# Altium schematic sheet Coil Interface Board.SchDoc("Coil Interface Board")
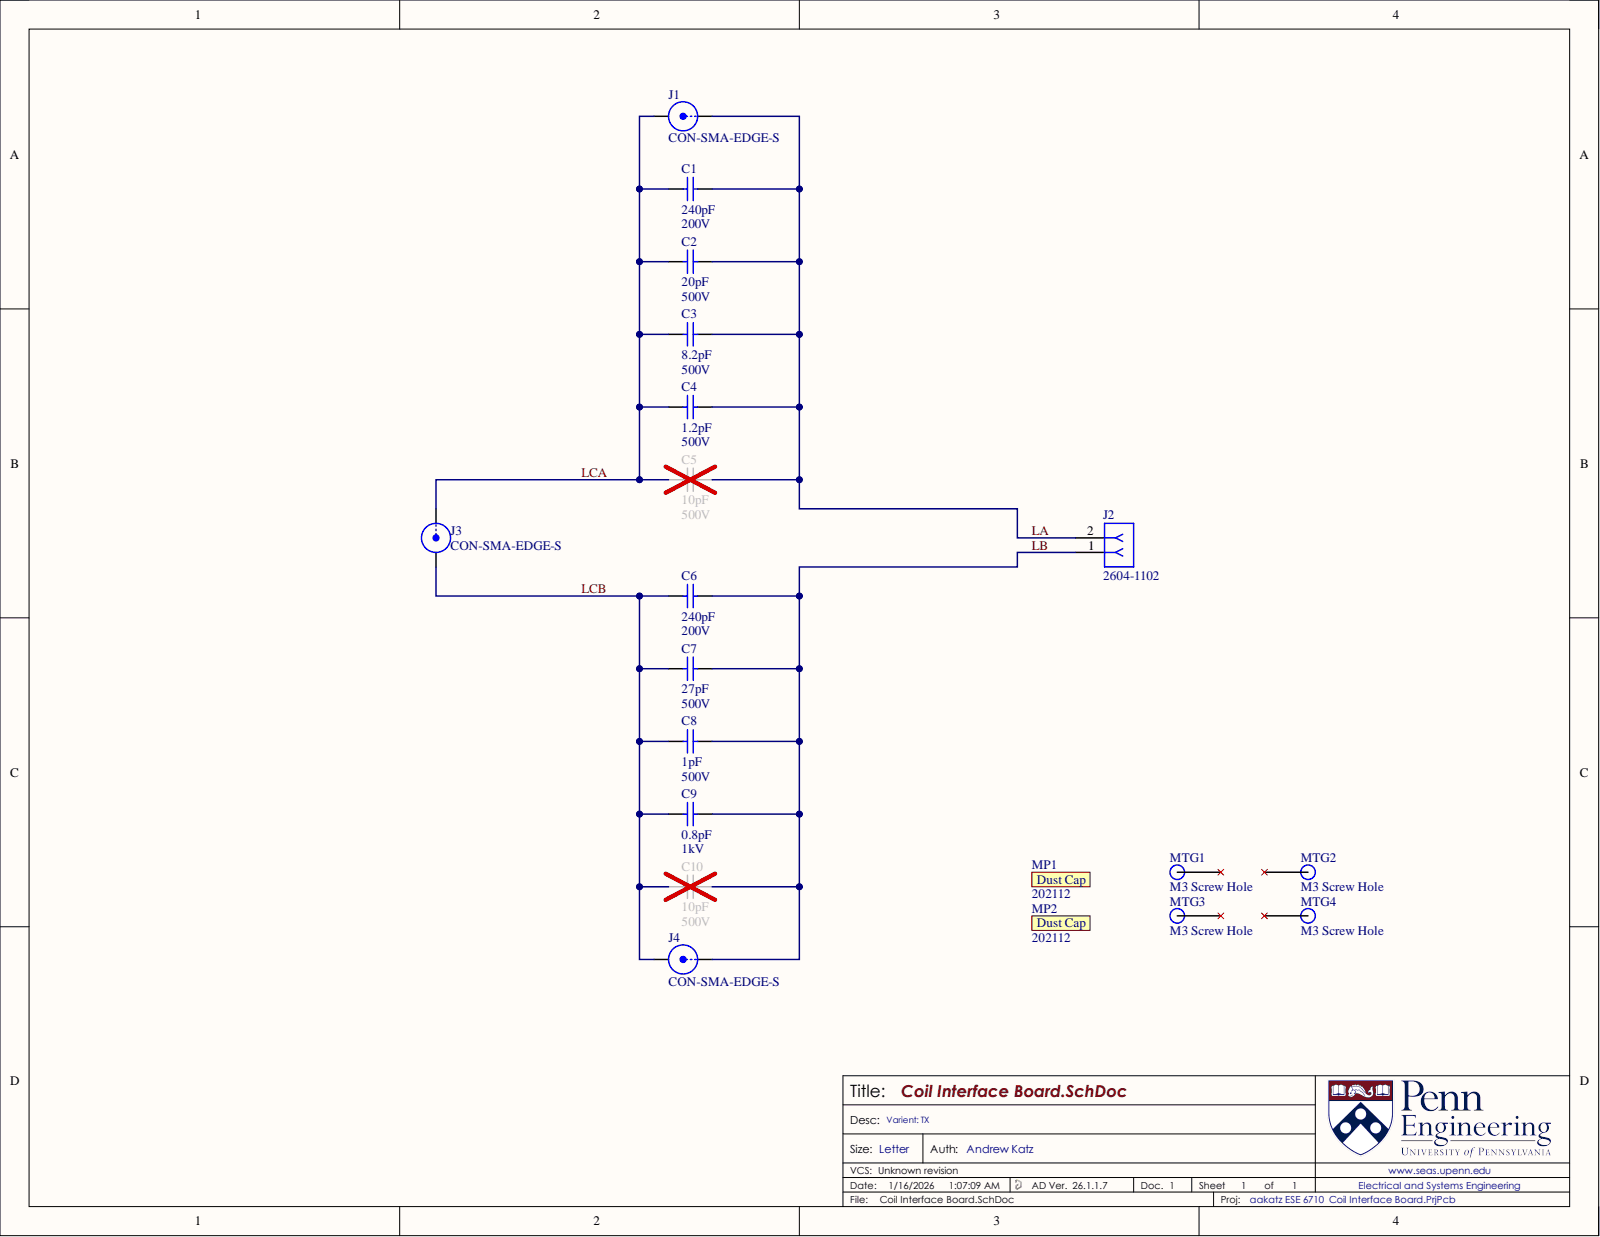
[diagram p0: Coil Interface Board.SchDoc]

## Parts
C1  value 240pF  [CAP_1111_ATC 800B]  (hidden comment: 800B241JT200XT)
C1
C1
C2  value 20pF  [CAP_1111_ATC 800B]  (hidden comment: 800B200JT500XT)
C2
C2
C3  value 8.2pF  Voltage: 500V  [CAP_1111_ATC 800B]  (hidden comment: 800B8R2BT500XT)
C3
C3
C4  value 1.2pF  Voltage: 500V  [CAP_1111_ATC 800B]  (hidden comment: 800B1R2BT500XT)
C4
C4
C5  value 10pF  Voltage: 500V  [CAP_1111_ATC 800B]  (hidden comment: 800B100JT500XT1K)
C6  value 240pF  [CAP_1111_ATC 800B]  (hidden comment: 800B241JT200XT)
C6
C6
C7  value 27pF  [CAP_1111_ATC 800B]  (hidden comment: 800B270JT500XT1K)
C7
C7
C8  value 1pF  Voltage: 500V  [CAP_1111_ATC 800B]  (hidden comment: 800B1R0BT500XT1K)
C8
C8
C9  value 0.8pF  [0805_CAP]  (hidden comment: QCCT102Q0R8B1GV001E)
C9
C9
C10  value 10pF  [CAP_1111_ATC 800B]  (hidden comment: 800B100JT500XT1K)
J1  CON-SMA-EDGE-S  [FP-SMA-J-P-H-ST-EM1-MFG]
J2  2604-1102  [2604-1102_MFG]
J3  CON-SMA-EDGE-S  [FP-SMA-J-P-H-ST-EM1-MFG]
J4  CON-SMA-EDGE-S  [FP-SMA-J-P-H-ST-EM1-MFG]
MP1  202112  (mechanical)
MP2  202112  (mechanical)
MTG1  M3 Screw Hole  [M3 Screw Hole-Footprint-1]  (no BOM)
MTG2  M3 Screw Hole  [M3 Screw Hole-Footprint-1]  (no BOM)
MTG3  M3 Screw Hole  [M3 Screw Hole-Footprint-1]  (no BOM)
MTG4  M3 Screw Hole  [M3 Screw Hole-Footprint-1]  (no BOM)

## Nets
LA: C1.2 C2.2 C3.2 C4.2 C5.2 J1.1 J2.2
LB: C6.2 C7.2 C8.2 C9.2 C10.2 J2.1 J4.1
LCA: C1.1 C2.1 C3.1 C4.1 C5.1 J1.2 J3.1
LCB: C6.1 C7.1 C8.1 C9.1 C10.1 J3.2 J4.2
NetMTG1_1: MTG1.1
NetMTG2_1: MTG2.1
NetMTG3_1: MTG3.1
NetMTG4_1: MTG4.1

## Library data of the parts
C1 C6 = CMP-001-00046-2: Ceramic Capacitor, Multilayer, Ceramic, 200V, 5% +Tol, 5% -Tol, C0G, 30ppm/Cel TC, 0.00024uF, Surface Mount, 1111; Capacitor Type: Ceramic; Case/Package: 1111; China RoHS: Compliant; Component Kind: Standard; Dielectric Material: Ceramic; Height: 1.78mm; Length: 2.79mm; Library Name: University of Pennsylvania (ESE); Lifecycle Status: Production; Max Operating Temperature: 125°C; Min Operating Temperature: -55°C; Mount: SMD; Mounting Technology: Surface Mount; Number of Terminals: 2; REACH SVHC: Yes; RoHS: Compliant; Tolerance: 5%; Voltage: 200V; Voltage Rating (DC): 200V; Width: 2.79mm; Case Code (Imperial): 1111; Case Code (Metric): 2828; Composition: Ceramic; Dielectric: C0G; Termination: SMD/SMT
C2 = CMP-001-00049-1: Ceramic Capacitor, Multilayer, Ceramic, 500V, 5% +Tol, 5% -Tol, C0G, 30ppm/Cel TC, 0.00002uF, Surface Mount, 1111; Capacitor Type: Ceramic; Case/Package: 1111; China RoHS: Compliant; Component Kind: Standard; Dielectric Material: Ceramic; Height: 1.78mm; Length: 2.79mm; Library Name: University of Pennsylvania (ESE); Lifecycle Status: Production; Max Operating Temperature: 125°C; Min Operating Temperature: -55°C; Mount: SMD; Mounting Technology: Surface Mount; Number of Terminals: 2; REACH SVHC: Yes; RoHS: Compliant; Tolerance: 5%; Voltage: 500V; Voltage Rating (DC): 500V; Width: 2.79mm; Case Code (Imperial): 1111; Case Code (Metric): 2828; Composition: Ceramic; Dielectric: C0G; Termination: SMD/SMT; Thickness: 1.778mm; Voltage Rating: 500V
C3 = CMP-001-00050-1: Multilayer Ceramic Capacitors MLCC - SMD/SMT 500volts 8.2pF NP0; Tolerance: 41.6667%; Dielectric: C0G; Capacitor Type: Ceramic; Case/Package: 1111; China RoHS: Compliant; Component Kind: Standard; Dielectric Material: Ceramic; Height: 1.78mm; Length: 2.79mm; Library Name: University of Pennsylvania (ESE); Lifecycle Status: Production; Max Operating Temperature: 125°C; Min Operating Temperature: -55°C; Mount: SMD; Mounting Technology: Surface Mount; Number of Terminals: 2; REACH SVHC: Yes; RoHS: Compliant; Voltage Rating (DC): 500V; Width: 2.79mm; Case Code (Imperial): 1111; Case Code (Metric): 2828; Composition: Ceramic; Schedule B: 8532240020; Termination: SMD/SMT; Thickness: 1.778mm; Voltage Rating: 500V
C4 = CMP-001-00044-1: Ceramic Capacitor, Multilayer, Ceramic, 500V, 41.6667% +Tol, 41.6667% -Tol, C0G, 30ppm/Cel TC, 0.0000012uF, Surface...; Capacitor Type: Ceramic; Case/Package: 1111; China RoHS: Compliant; Component Kind: Standard; Dielectric Material: Ceramic; Height: 1.78mm; Length: 2.79mm; Library Name: University of Pennsylvania (ESE); Lifecycle Status: Production; Max Operating Temperature: 125°C; Min Operating Temperature: -55°C; Mount: SMD; Mounting Technology: Surface Mount; Number of Terminals: 2; REACH SVHC: Yes; RoHS: Compliant; Tolerance: 41.6667%; Voltage Rating (DC): 500V; Width: 2.79mm; Case Code (Imperial): 1111; Case Code (Metric): 2828; Termination: SMD/SMT; Thickness: 1.778mm; Voltage Rating: 500V
C5 C10 = CMP-001-00027-1: Ceramic Capacitor, Multilayer, Ceramic, 500V, 5% +Tol, 5% -Tol, C0G, 30ppm/Cel TC, 0.00001uF, Surface Mount, 1111; Capacitor Type: Ceramic; Case/Package: 1111; China RoHS: Compliant; Component Kind: Standard; Dielectric Material: Ceramic; Height: 1.78mm; Length: 2.79mm; Library Name: University of Pennsylvania (ESE); Lifecycle Status: Production; Max Operating Temperature: 125°C; Min Operating Temperature: -55°C; Mount: SMD; Mounting Technology: Surface Mount; Number of Terminals: 2; REACH SVHC: Yes; RoHS: Compliant; Voltage Rating (DC): 500V; Width: 2.79mm
  C10: Voltage: 500V
C7 = CMP-001-00030-1: Ceramic Capacitor, Multilayer, Ceramic, 500V, 5% +Tol, 5% -Tol, C0G, 30ppm/Cel TC, 0.000027uF, Surface Mount, 1111; Capacitor Type: Ceramic; Case/Package: 1111; China RoHS: Compliant; Component Kind: Standard; Dielectric Material: Ceramic; Height: 1.78mm; Length: 2.79mm; Library Name: University of Pennsylvania (ESE); Lifecycle Status: Production; Max Operating Temperature: 125°C; Min Operating Temperature: -55°C; Mount: SMD; Mounting Technology: Surface Mount; Number of Terminals: 2; REACH SVHC: Yes; RoHS: Compliant; Voltage: 500V; Voltage Rating (DC): 500V; Width: 2.79mm
C8 = CMP-001-00029-1: Ceramic Capacitor, Multilayer, Ceramic, 500V, 50% +Tol, 50% -Tol, C0G, 30ppm/Cel TC, 0.000001uF, Surface Mount, 1111; Capacitor Type: Ceramic; Case/Package: 1111; China RoHS: Compliant; Component Kind: Standard; Dielectric Material: Ceramic; Height: 1.78mm; Length: 2.79mm; Library Name: University of Pennsylvania (ESE); Lifecycle Status: Production; Max Operating Temperature: 125°C; Min Operating Temperature: -55°C; Mount: SMD; Mounting Technology: Surface Mount; Number of Terminals: 2; REACH SVHC: Yes; RoHS: Compliant; Voltage Rating (DC): 500V; Width: 2.79mm
C9 = CMP-001-00048-1: Ceramic Capacitor, Multilayer, Ceramic, 1000V, 12.5% +Tol, 12.5% -Tol, C0G, 30ppm/Cel TC, 0.0000008uF, Surface Mount,...; Tolerance: 16.6667%; Voltage: 1kV; Capacitor Type: Ceramic; Case/Package: 0805; China RoHS: Compliant; Component Kind: Standard; Dielectric Material: Ceramic; Height: 1.02mm; Length: 2.03mm; Library Name: University of Pennsylvania (ESE); Lifecycle Status: Production; Max Operating Temperature: 125°C; Min Operating Temperature: -55°C; Mount: SMD; Mounting Technology: Surface Mount; Number of Terminals: 2; REACH SVHC: Yes; RoHS: Yes; Voltage Rating (DC): 1kV; Width: 1.27mm
J1 J3 J4 = CMP-00788-5: Sma Female Connector Jack, Pcb Edge Mount Rohs Compliant: Yes; Frequency: 18GHz; Impedance: 50Ω; Number of Contacts: 1; Case/Package: SMA; Component Kind: Standard; Contact Gender: Female; Contact Material: Gold; Height: 6.35mm; Library Name: University of Pennsylvania (ESE); Manufacturer Package Identifier: CON-SMA-EDGE-S; Number of Ports: 1; Pins: 1; RoHS: Compliant; Shell Material: Brass
J2 = CMP-00792-2: Pcb Terminal Block; Operating Lever; 4 MM²; Pin Spacing 5 Mm; 2-POLE; Push-in Cage Clamp®; Approvals: CB, CSA, KEMA, UL, cUL; Current Rating: 20A; Number of Contacts: 2; Number of Levels: 1; Voltage Rating: 300V; Wire/Cable Gauge: 12AWG; Color: Grey; Component Kind: Standard; Contact Material: Tin; Height: 25.7mm; Housing Color: Grey; Library Name: University of Pennsylvania (ESE); Lifecycle Status: Production; Max Operating Temperature: 105°C; Min Operating Temperature: -60°C; Number of Rows: 1; Pins: 2; REACH SVHC: Yes; RoHS: Compliant
MP1 MP2 = CMP-00829-1: Amphenol RF 202112 SMA Cap for Female Connector, Brass/Gold; Body Material: Brass; Body Plating: Gold; Connector Type: Coaxial, SMA; Gender: Male; Impedance: 50Ω; Case/Package: SMA; Color: Gold; Depth: 8mm; Exterior Housing Material: Brass; Lead Free: Lead Free; Length: 8.4mm; Library Name: University of Pennsylvania (ESE); Lifecycle Status: Production; Orientation: Straight; Packaging: Bulk; Radiation Hardening: No; RoHS: Compliant; Weight: 2.799997g
MTG1-MTG4 = CMP-00028-5: Close clearance Fit for M3 Screw Hole; PCB Mounting for M3 Screw; Library Name: University of Pennsylvania (ESE)
